# Altium schematic sheet compressor_Schematic.SchDoc(compressor_Schematic)
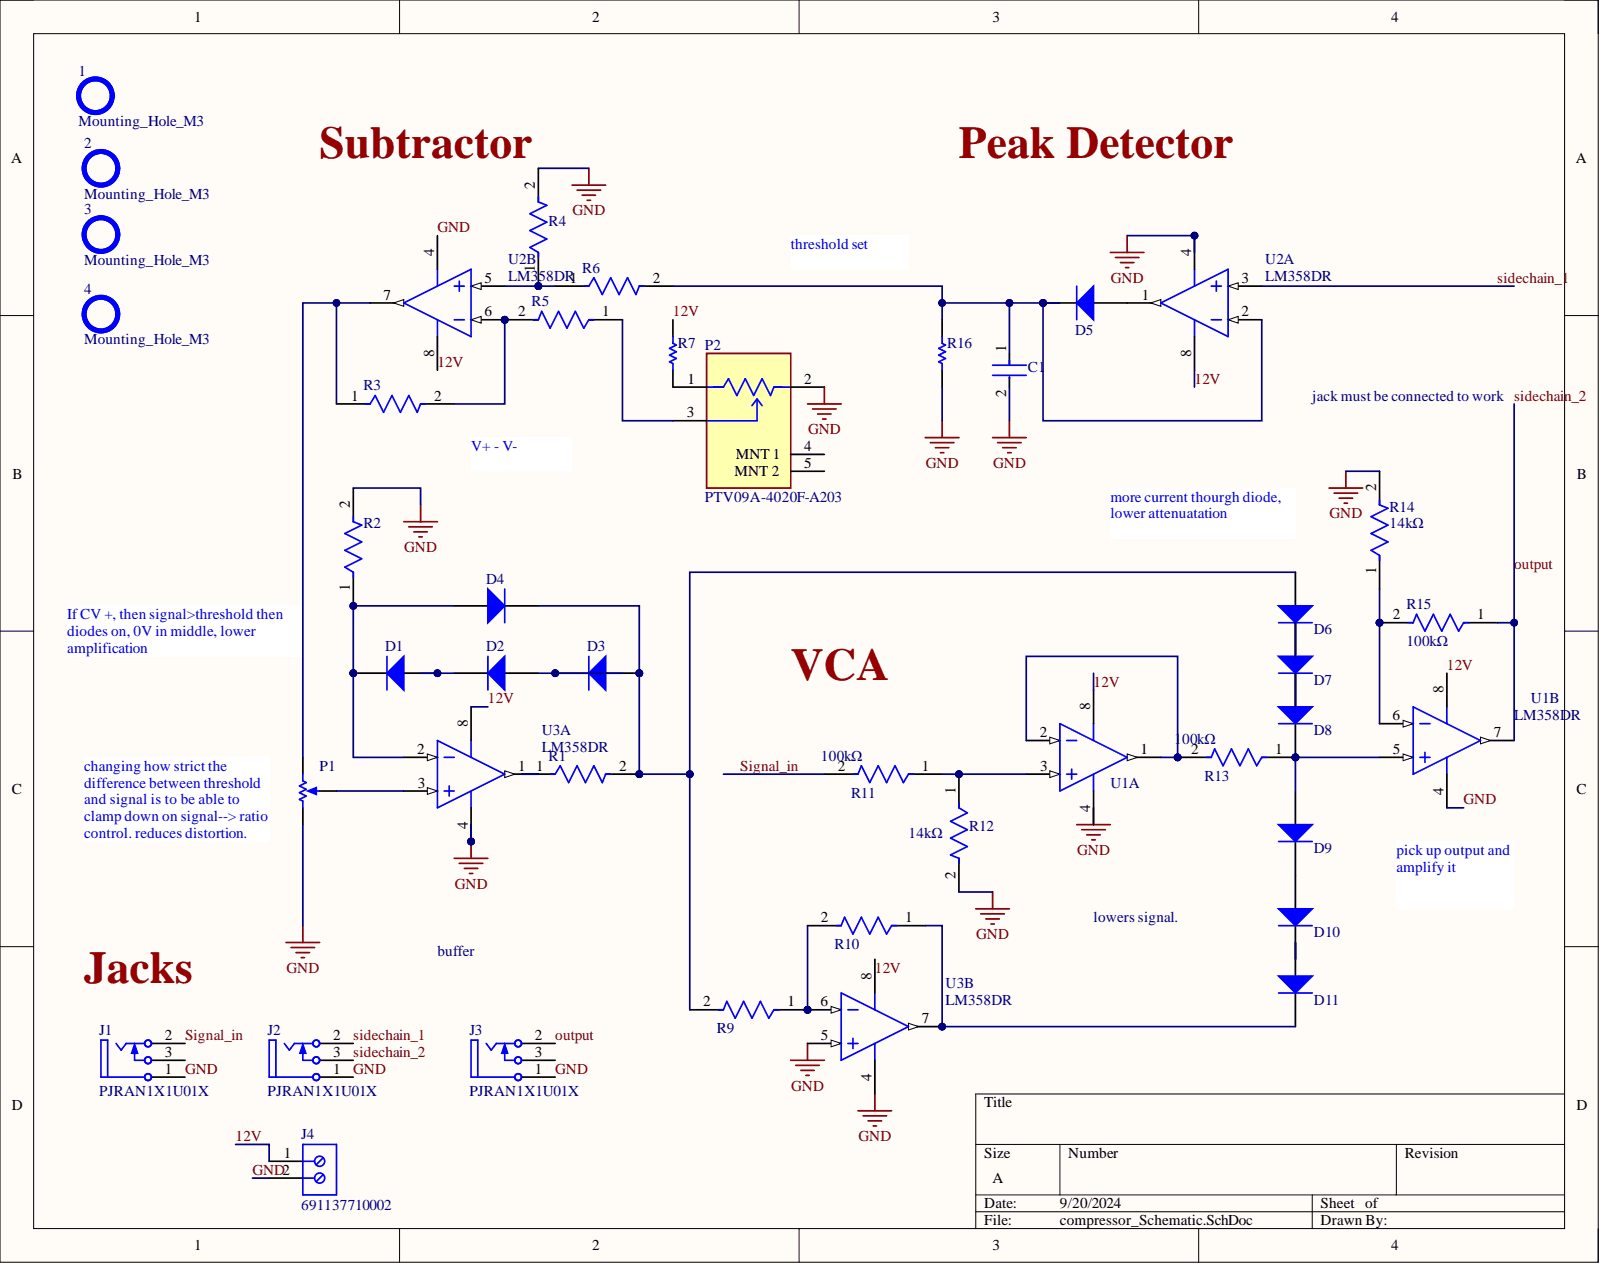
[diagram p0: compressor_Schematic.SchDoc]

## Parts
1  Mounting_Hole_M3
2  Mounting_Hole_M3
3  Mounting_Hole_M3
4  Mounting_Hole_M3
C1  GMK316AB7106KL-TR  (value not drawn)
D1  1N4148WS-7-F  (value not drawn)
D2  1N4148WS-7-F  (value not drawn)
D3  1N4148WS-7-F  (value not drawn)
D4  1N4148WS-7-F  (value not drawn)
D5  1N4148WS-7-F  (value not drawn)
D6  1N4148WS-7-F  (value not drawn)
D7  1N4148WS-7-F  (value not drawn)
D8  1N4148WS-7-F  (value not drawn)
D9  1N4148WS-7-F  (value not drawn)
D10  1N4148WS-7-F  (value not drawn)
D11  1N4148WS-7-F  (value not drawn)
J1  PJRAN1X1U01X
J2  PJRAN1X1U01X
J3  PJRAN1X1U01X
J4  691137710002
P1  3362P-1-104LF  (value not drawn)
P2  PTV09A-4020F-A203
R1  MRS25000C1001FCT00  (value not drawn)
R2  RLR07C1003FSB14  (value not drawn)
R3  RLR07C1003FSB14  (value not drawn)
R4  RLR07C1003FSB14  (value not drawn)
R5  RLR07C1003FSB14  (value not drawn)
R6  RLR07C1003FSB14  (value not drawn)
R7  ERJ-3EKF2002V  (value not drawn)
R9  RLR07C1003FSB14  (value not drawn)
R10  RLR07C1003FSB14  (value not drawn)
R11  Resistance: 100kΩ  (hidden comment: RLR07C1003FSB14)
R12  Resistance: 14kΩ  (hidden comment: RLR07C1003FSB14)
R13  Resistance: 100kΩ  (hidden comment: RLR07C1003FSB14)
R14  Resistance: 14kΩ  (hidden comment: RLR07C1003FSB14)
R15  Resistance: 100kΩ  (hidden comment: RLR07C1003FSB14)
R16  OD103JE  (value not drawn)
U1  LM358DR  Nominal Dual Supply Voltage: 12V  (parts A,B)
U2  LM358DR  Nominal Dual Supply Voltage: 12V  (parts A,B)
U3  LM358DR  Nominal Dual Supply Voltage: 12V  (parts A,B)

## Nets
12V: J4.1 R7.2 U1.8 U2.8 U3.8
GND: C1.2 J1.1 J2.1 J3.1 J4.2 P1.1 P2.2 R2.2 R4.2 R12.2 R14.2 R16.1 U1.4 U2.4 U3.4 U3.5
output: J2.3 J3.2 R15.1 U1.7
sidechain_1: J2.2 U2.3
Signal_in: J1.2 R11.2
NetC1_1: C1.1 D5.2 R6.2 R16.2 U2.2
NetD1_1: D1.1 D2.2
NetD1_2: D1.2 D4.1 R2.1 U3.2
NetD2_1: D2.1 D3.2
NetD3_1: D3.1 D4.2 D6.1 R1.2 R9.2
NetD5_1: D5.1 U2.1
NetD6_2: D6.2 D7.1
NetD7_2: D7.2 D8.1
NetD8_2: D8.2 D9.1 R13.1 U1.5
NetD9_2: D9.2 D10.1
NetD10_2: D10.2 D11.1
NetD11_2: D11.2 R10.1 U3.7
NetP1_2: P1.2 U3.3
NetP1_3: P1.3 R3.1 U2.7
NetP2_1: P2.1 R7.1
NetP2_3: P2.3 R5.1
NetR1_1: R1.1 U3.1
NetR3_2: R3.2 R5.2 U2.6
NetR4_1: R4.1 R6.1 U2.5
NetR9_1: R9.1 R10.2 U3.6
NetR11_1: R11.1 R12.1 U1.3
NetR13_2: R13.2 U1.1 U1.2
NetR14_1: R14.1 R15.2 U1.6

Not connected: J1 3; J3 3; P2 4(MNT_1) 5(MNT_2)

## Library data of the parts
C1 = CMP-2000-06324-3: None; Capacitance: 10uF; Dielectric: X7R; Tolerance: 10%; Voltage Rating: 35V; Case Code (Imperial): 1206; Case Code (Metric): 3216; Case/Package: 1206; Component Kind: Standard; Height: 1.6mm; Length: 0.126inch; Library Name: Altium Content Vault; Max Operating Temperature: 125°C; Min Operating Temperature: -55°C; Mount: Surface Mount; Package Quantity: 2000; Packaging: Tape and Reel; REACH SVHC: No SVHC; Resistor Type: Ceramic; RoHS Compliant: Yes; Series: M; Termination: SMD/SMT; Thickness: 0.071inch; Voltage Rating (DC): 35V; Width: 1.6mm
D1-D11 = CMP-004-00002-2: Fast Switching Diode, 75 V, 0.3 A, -65 to 150 degC, 2-Pin SOD323, RoHS, Tape and Reel; Manufacturer: Diodes Inc; Elements: 1; Forward Current: 300mA; Forward Voltage: 1.25V; Max Surge Current: 2A; Peak Reverse Current: 1uA; Recovery Time: 4ns; Case/Package: SOD; Component Kind: Standard; Datasheet URL: http://www.diodes.com/datasheets/ds30097.pdf; Datasheet Version: Rev. 25-2, 03/2016; Manufacturer URL: http://www.diodes.com/; Max Operating Temperature: 150°C; Min Operating Temperature: -65°C; Mounting Technology: SM; Package Description: 2-Pin Small Outline Diode, Body 1.7 x 1.3 mm; Package Quantity: 3000; Package Reference: SOD323-2; Packaging: TapeandReel; Pins: 2; RoHS Compliant: True; Library Name: Americas- Cooper Union Motorsports Team- The Cooper Union
J1-J3 = CMP-1772-00003-1: Female Phono Jack, 3 Position, Height 13 mm, Tail Length 4 mm, RoHS, Bulk; Capacitance: 100 nF; Case\Package: Radial; Tolerance: 10%; Type (Capacitors): X7R; Voltage Rating: 50 V; Component Kind: Standard; ComponentLink1Description: Manufacturer URL; ComponentLink1URL: http://www.switchcraft.com/; ComponentLink2Description: Datasheet; ComponentLink2URL: http://www.conxall.com/Drawings/pjran1x1u__x_series_cd.pdf; DatasheetVersion: Rev. A, 01/2006; Height: 3.18 mm; Lead Pitch: 2.54 mm; Length: 6.6 mm; Library Name: Altium Content Vault; Max Operating Temperature: 125 degC; Min Operating Temperature: -55 degC; Mount: Through Hole; Package Quantity: 500; PackageDescription: Female Phono Jack, 3 Position, Height 13 mm, Tail Length 4 mm; PackageReference: PJRAN1X1U01X; Packaging: Bulk; Pins: 2; Radiation Hardening: No; REACH SVHC: No SVHC; RoHSCompliant: true; Voltage Rating (DC): 50 V; Width: 5.08 mm
J4 = CMP-1502-03324-2: NexarPartId: 78871135; Current Rating: 16A; Number of Contacts: 2; Voltage Rating: 300V; Component Kind: Standard; Contact Material: Nickel, Tin; Height: 11.6mm; Lead Pitch: 5mm; Library Name: Altium Content Vault; Max Operating Temperature: 120°C; Min Operating Temperature: -30°C; Number of Pins: 2; Number of Rows: 1; Packaging: Box; RoHS: Non-Compliant
P1 = CMP-1747-00003-1: Square Trimpot(R) Trimming Potentiometer, 100 KOhm, 300 V, -55 to 125 degC, 3-Pin THD, RoHS, Tube; Case\Package: Axial; Power Rating: 250 mW; Resistance: 1 mohm; Tolerance: 1%; Voltage Rating: 250 V; Component Kind: Standard; ComponentLink1Description: Manufacturer URL; ComponentLink1URL: http://www.bourns.com/; ComponentLink2Description: Datasheet; ComponentLink2URL: http://www.bourns.com/docs/Product-Datasheets/3362.pdf; DatasheetVersion: May-16; Length: 0.248 inch; Library Name: Altium Content Vault; Max Operating Temperature: 155 degC; Min Operating Temperature: -55 degC; Mount: Through Hole; Package Quantity: 200; PackageDescription: 3-Pin Through Hole Device, Body 6.6 x 6.99 mm, Pitch 2.54 mm; PackageReference: 3326P; Packaging: Bulk; RoHSCompliant: true
P2 = CMP-07234-000017-1: POT 20K OHM 1/20W CARBON LOG; Manufacturer: Bourns Inc.; Power Rating: 0.05W; Resistance: 20kΩ; Tolerance: 20%; Value: 20kΩ; Voltage Rating: 50V; Automotive: No; Category: Res; Component Kind: Standard; ComponentLink2Description: Datasheet; ComponentLink2URL: https://www.bourns.com/docs/product-datasheets/ptv09.pdf?sfvrsn=5e1089f1_12; Composition: Carbon; Device Class L1: Passive Components; Device Class L2: Resistors; Device Class L3: Variable Resistors and Potentiometers; Digikey Description: POT 20K OHM 1/20W CARBON LOG; Height: 20.5mm; Lead Free: Yes; Library Name: Altium Content Vault; Manufacturer Part Number: PTV09A-4020F-A203; Mouser Description: Potentiometers 20K AUDIO 20%; Octopart: https://octopart.com/ptv09a-4020f-a203-bourns-1637636; Package: PTV09A-4020; RoHS: TRUE; Series: PTV09; Temperature: -10°C to +50°C; Temperature Range High: +50°C; Temperature Range Low: -10°C
R1 = CMP-02408-000051-1: RES 1K OHM 0.6W 1% AXIAL; Lead Diameter: 0.6mm; Max Power Dissipation: 600mW; Resistance: 1kR; Temperature Coefficient: 50ppm/°C; Voltage Rating: 350V; Case/Package: Axial; Component Kind: Standard; Construction: Cylindrical; Contact Plating: Tin; Diameter: 2.5mm; Lead Free: Lead Free; Length: 6.5mm; Library Name: Altium Content Vault; Max Operating Temperature: 155°C; Military Standard: Not; Min Operating Temperature: -55°C; Mount: Through Hole; Number of Pins: 2; Number of Terminations: 2; Package Quantity: 5000; Packaging: Tape and Reel; Power Rating: 600mW; Radiation Hardening: No; Resistance Tolerance: 1%; Resistor Type: Metal Film; RoHS Compliant: Yes; Series: MRS25; Technology: THIN FILM; Termination: Axial; Tolerance: 1%; Voltage Rating (DC): 350V; Weight: 0.00776oz; Width: 2.5mm
R2-R6 R9 R10 = CMP-00030-39453770-1: RLR07C1003FSB14 Resistor, 100 kOhm, ± 1%, 250 mW, Axial Leaded, Metal Film, Military Reliability; Lead Diameter: 640µm; Lead Length: 38.1mm; Max Power Dissipation: 250mW; Resistance: 100kΩ; Temperature Coefficient: 100ppm/°C; Voltage Rating: 250V; Wire/Cable Diameter: 50.8µm; Case/Package: Axial; Component Kind: Standard; Composition: Metal Film; Contact Plating: Lead, Tin; Diameter: 2.29mm; Failure Rate: 0.001%; Features: Military, Moisture Resistant, Weldable; Height: 2.5mm; Lead Free: Contains Lead; Length: 7.62mm; Library Name: Altium Content Vault; Max Operating Temperature: 150°C; Military Standard: MIL-PRF-39017; Min Operating Temperature: -65°C; NexarPartId: 39453770; Number of Terminations: 2; Packaging: Bulk; Power Rating: 250mW; Radiation Hardening: No; REACH SVHC: Unknown; RoHS: Non-Compliant; Schedule B: 8533210090, 8533210090; Termination: Axial; Tolerance: 1%; Voltage Rating (DC): 250V; Width: 2.29mm
R7 = CMP-2000-00318-1: Manufacturer: Panasonic; Component Kind: Standard; Library Name: Altium Content Vault; Part Number: ERJ-3EKF2002V
R11-R15 = CMP-00030-39453770-1: RLR07C1003FSB14 Resistor, 100 kOhm, ± 1%, 250 mW, Axial Leaded, Metal Film, Military Reliability; Lead Diameter: 640µm; Lead Length: 38.1mm; Max Power Dissipation: 250mW; Temperature Coefficient: 100ppm/°C; Voltage Rating: 250V; Wire/Cable Diameter: 50.8µm; Case/Package: Axial; Component Kind: Standard; Composition: Metal Film; Contact Plating: Lead, Tin; Diameter: 2.29mm; Failure Rate: 0.001%; Features: Military, Moisture Resistant, Weldable; Height: 2.5mm; Lead Free: Contains Lead; Length: 7.62mm; Library Name: Altium Content Vault; Max Operating Temperature: 150°C; Military Standard: MIL-PRF-39017; Min Operating Temperature: -65°C; NexarPartId: 39453770; Number of Terminations: 2; Packaging: Bulk; Power Rating: 250mW; Radiation Hardening: No; REACH SVHC: Unknown; RoHS: Non-Compliant; Schedule B: 8533210090, 8533210090; Termination: Axial; Tolerance: 1%; Voltage Rating (DC): 250V; Width: 2.29mm
R16 = CMP-2000-06865-1: Little Demon(R) Carbon Composition Molded OD Series, 250 V, 10 KOhm, +/-5%, -70 to 130 degC, 2-Pin THD, RoHS, Bulk; Manufacturer: Ohmite; Catalog Drawings: OD,OF,OASeries; EDA / CAD Models: DownloadfromAcceleratedDesigns; Online Catalog: OD,OF,OASeries,LittleDemon®; Power (Watts): 0.25W,1/4W; Power Rating: 0.25W; Resistance (Ohms): 10k; Tolerance: ±5%; Category: Resistors; Component Kind: Standard; Composition: CarbonComposition; Datasheet URL: http://www.ohmite.com/cat/res_od_of_oa.pdf; Datasheet Version: 06/2016; Family: ThroughHoleResistors; Features: PulseWithstanding; Height: -; Library Name: Altium Content Vault; Manufacturer URL: http://www.ohmite.com/; Mounting Technology: ThroughHole; Number of Terminations: 2; Operating Temperature: -; Package / Case: Axial; Package Description: 2-Pin THD Resistor, Body 7 x 2.5 mm, Lead Dia. 0.6 mm; Package Reference: RES7X2.5; Packaging: Bulk; PartNumber: OD103JE; RoHS Information: LittleDemon"E"SeriesMaterialDeclaration; Series: LittleDemon®OD; Size / Dimension: 0.098"Diax0.276"L(2.50mmx7.00mm); Standard Package: 100; Supplier Device Package: Axial; Temperature Coefficient: -
U1-U3 = CMP-014-00024-2: Dual Operational Amplifier, 3 to 32 V, 0 to 70 degC, 8-Pin SOIC (D), Green (RoHS & no Sb/Br), Tape and Reel; Manufacturer: Texas Instruments (TI); Channels: 2; Common Mode Rejection Ratio: 65dB; Max Dual Supply Voltage: 16V; Min Dual Supply Voltage: 1.5V; Nominal Gain Bandwith Product: 700KHz; Nominal Supply Current: 1.2mA; Nominal Voltage Gain: 100dB; Output Current: 30mA; Package Version: 4040047-3/M, 06/2011; Slew Rate: 0.3V/us; Case/Package: SOIC; Component Kind: Standard; Datasheet URL: http://www.ti.com/lit/ds/symlink/lm358.pdf; Datasheet Version: SLOS068T, 04/2015; Manufacturer URL: http://www.ti.com/; Max Operating Temperature: 70°C; Max Supply Voltage: 16V; Min Operating Temperature: 0°C; Min Supply Voltage: 1.5V; Mounting Technology: SM; Nominal Supply Voltage: 12V; Package Description: 8-Pin Small Outline Integrated Circuit, Body 4.9 x 3.9 mm, Pitch 1.27 mm; Package Quantity: 1; Package Reference: D0008A; Package URL: http://www.ti.com/lit/ml/msoi002j/msoi002j.pdf; Packaging: CutTape; Pins: 8; RoHS Compliant: True; Unity Gain Bandwidth Product: 700KHz; Library Name: Americas- Cooper Union Motorsports Team- The Cooper Union
1-4 = Mounting_Hole_M3: Component Kind: Standard; Library Name: CANBUS_parts.SchLib
